# Altium schematic sheet Sheet1.SchDoc(Sheet1)
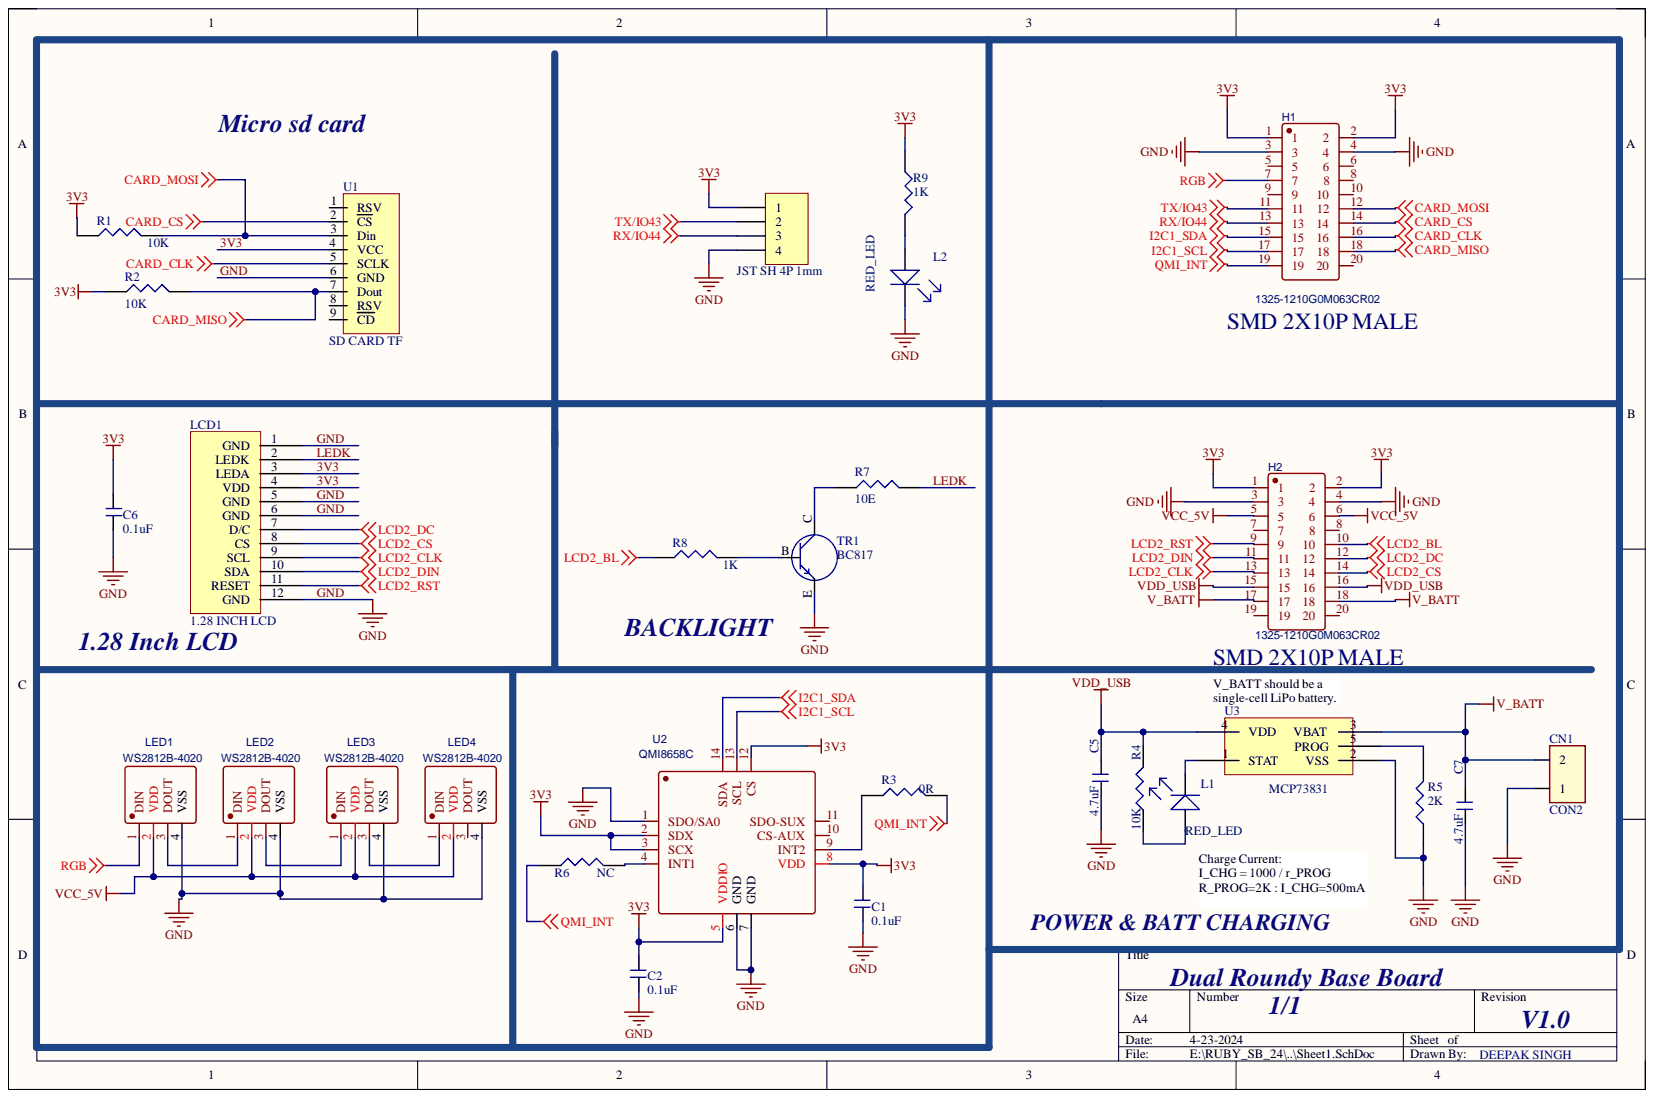
[diagram p0: Sheet1.SchDoc]

## Parts
C1  0.1uF  [C_0603]
C2  0.1uF  [C_0603]
C5  4.7uF  [C_0603]
C6  0.1uF  [C_0603]
C7  4.7uF  [C_0603]
CN1  CON2  [GH 1X2P 1mm]
H1  1325-1210G0M063CR02  [SMD 2X10P 1.27MM MAL]
H2  1325-1210G0M063CR02  [SMD 2X10P 1.27MM MAL]
JST_SH_4P_1mm  Header 4  [SH 1x4P 1mm]  (value not drawn)
L1  RED_LED  [LED_0603]
L2  RED_LED  [LED_0603]
LCD1  1.28 INCH LCD  [1.28 ROUND NON TOUCH]
LED1  WS2812B-4020  [SIDE_RGB]
LED2  WS2812B-4020  [SIDE_RGB]
LED3  WS2812B-4020  [SIDE_RGB]
LED4  WS2812B-4020  [SIDE_RGB]
R1  10K  [R_0603]
R2  10K  [R_0603]
R3  0R  [R_0603]
R4  10K  [R_0603]
R5  2K  [R_0603]
R6  NC  [R_0603]
R7  10E  [R_0603]
R8  1K  [R_0603]
R9  1K  [R_0603]
TR1  BC817  [SOT23]
U1  SD CARD TF  [micro sd card]
U2  QMI8658C  [LGA-14]
U3  MCP73831  [SOT23-5]

## Nets
CARD_CLK: H1.16 U1.5(SCLK)
CARD_CS: H1.14 U1.2(CS)
CARD_MISO: H1.18 R2.2 U1.7(Dout)
CARD_MOSI: H1.12 R1.2 U1.3(Din)
I2C1_SCL: H1.17 U2.13(SCL)
I2C1_SDA: H1.15 U2.14(SDA)
LCD2_BL: H2.10 R8.1
LCD2_CLK: H2.13 LCD1.9(SCL)
LCD2_CS: H2.14 LCD1.8(CS)
LCD2_DC: H2.12 LCD1.7(D/C)
LCD2_DIN: H2.11 LCD1.10(SDA)
LCD2_RST: H2.9 LCD1.11(RESET)
QMI_INT: H1.19 R3.2 R6.1
RGB: H1.7 LED1.1(DIN)
RX/IO44: H1.13 JST_SH_4P_1mm.3
TX/IO43: H1.11 JST_SH_4P_1mm.2
V_BATT: C7.1 CN1.2 H2.17 H2.18 U3.3(VBAT)
VCC_5V: H2.5 H2.6 LED1.2(VDD) LED2.2(VDD) LED3.2(VDD) LED4.2(VDD)
VDD_USB: C5.1 H2.15 H2.16 R4.2 U3.4(VDD)
3V3: C1.1 C2.1 C6.2 H1.1 H1.2 H2.1 H2.2 JST_SH_4P_1mm.1 LCD1.3(LEDA) LCD1.4(VDD) R1.1 R2.1 R9.1 U1.4(VCC) U2.2(SDX) U2.3(SCX) U2.5(VDDIO) U2.8(VDD) U2.12(CS)
GND: C1.2 C2.2 C5.2 C6.1 C7.2 CN1.1 H1.3 H1.4 H2.3 H2.4 JST_SH_4P_1mm.4 L2.K LCD1.1(GND) LCD1.5(GND) LCD1.6(GND) LCD1.12(GND) LED1.4(VSS) LED2.4(VSS) LED3.4(VSS) LED4.4(VSS) R5.1 TR1.E U1.6(GND) U2.1(SDO/SA0) U2.6(GND) U2.7(GND) U3.2(VSS)
LEDK: LCD1.2(LEDK) R7.2
NetL1_A: L1.A R4.1
NetL1_K: L1.K U3.1(STAT)
NetL2_A: L2.A R9.2
NetLED1_3: LED1.3(DOUT) LED2.1(DIN)
NetLED2_3: LED2.3(DOUT) LED3.1(DIN)
NetLED3_3: LED3.3(DOUT) LED4.1(DIN)
NetR3_1: R3.1 U2.9(INT2)
NetR5_2: R5.2 U3.5(PROG)
NetR6_2: R6.2 U2.4(INT1)
NetR7_1: R7.1 TR1.C
NetR8_2: R8.2 TR1.B

Not connected: H1 5 6 8 9 10 20; H2 7 8 19 20; LED4 3(DOUT); U1 1(RSV) 8(RSV) 9(CD); U2 10(CS-AUX) 11(SDO-SUX)

## Library data of the parts
C1 C2 C5-C7 = CAP_NONPOLAR: Component Kind: Standard; Library Name: dxp_pasl_lib.IntLib
H1 H2 = 1325-1210G0M063CR02: BOM_Manufacturer: Wcon(维峰电子); Contributor: LCSC; BOM_JLCPCB Part Class: Extended Part; BOM_Manufacturer Part: 1325-1210G0M063CR02; BOM_Supplier: LCSC; BOM_Supplier Part: C920905; Component Kind: Standard; spicePre: H; spiceSymbolName: 1325-1210G0M063CR02
JST_SH_4P_1mm = Header 4: Header, 4-Pin; Component Kind: Standard; LatestRevisionDate: 17-Jul-2002; LatestRevisionNote: Re-released for DXP Platform.; Library Name: Miscellaneous Connectors.IntLib; Signal Integrity: Connector; Publisher: Altium Limited
L1 L2 = LED: Component Kind: Standard; Library Name: dxp_pasl_lib.IntLib
LCD1 = LCD ROUND: 1.28 INCH ROUND LCD GC9A01; Component Kind: Standard; Library Name: dxp_pasl_lib.IntLib
LED1-LED4 = WS2812B-4020: BOM_Manufacturer: worldsemi; Contributor: LCSC; BOM_JLCPCB Part Class: Extended Part; BOM_Manufacturer Part: WS2812B-4020; BOM_Supplier: LCSC; BOM_Supplier Part: C965557; Component Kind: Standard; spicePre: L; spiceSymbolName: WS2812B-4020; Library Name: MIX.SchLib
R1-R9 = RES: Component Kind: Standard; Library Name: dxp_pasl_lib.IntLib
TR1 = TRANSISTER_NPN: Component Kind: Standard; Library Name: dxp_pasl_lib.IntLib
U1 = SD CARD TF: Micro SD Memory Card Socket; Component Kind: Standard; Library Name: dxp_pasl_lib.IntLib
U2 = QMI8658C: BOM_Manufacturer: QST(上海矽睿); Contributor: LCSC; BOM_JLCPCB Part Class: Extended Part; BOM_Manufacturer Part: QMI8658C; BOM_Supplier: LCSC; BOM_Supplier Part: C2842151; Component Kind: Standard; spicePre: U; spiceSymbolName: QMI8658C
CN1 = CON2: Component Kind: Standard; Library Name: dxp_pasl_lib.IntLib
U3 = MCP73831: Component Kind: Standard; Library Name: dxp_pasl_lib.IntLib
